# Altium schematic sheet FPGA JTAG and FLASH.SchDoc
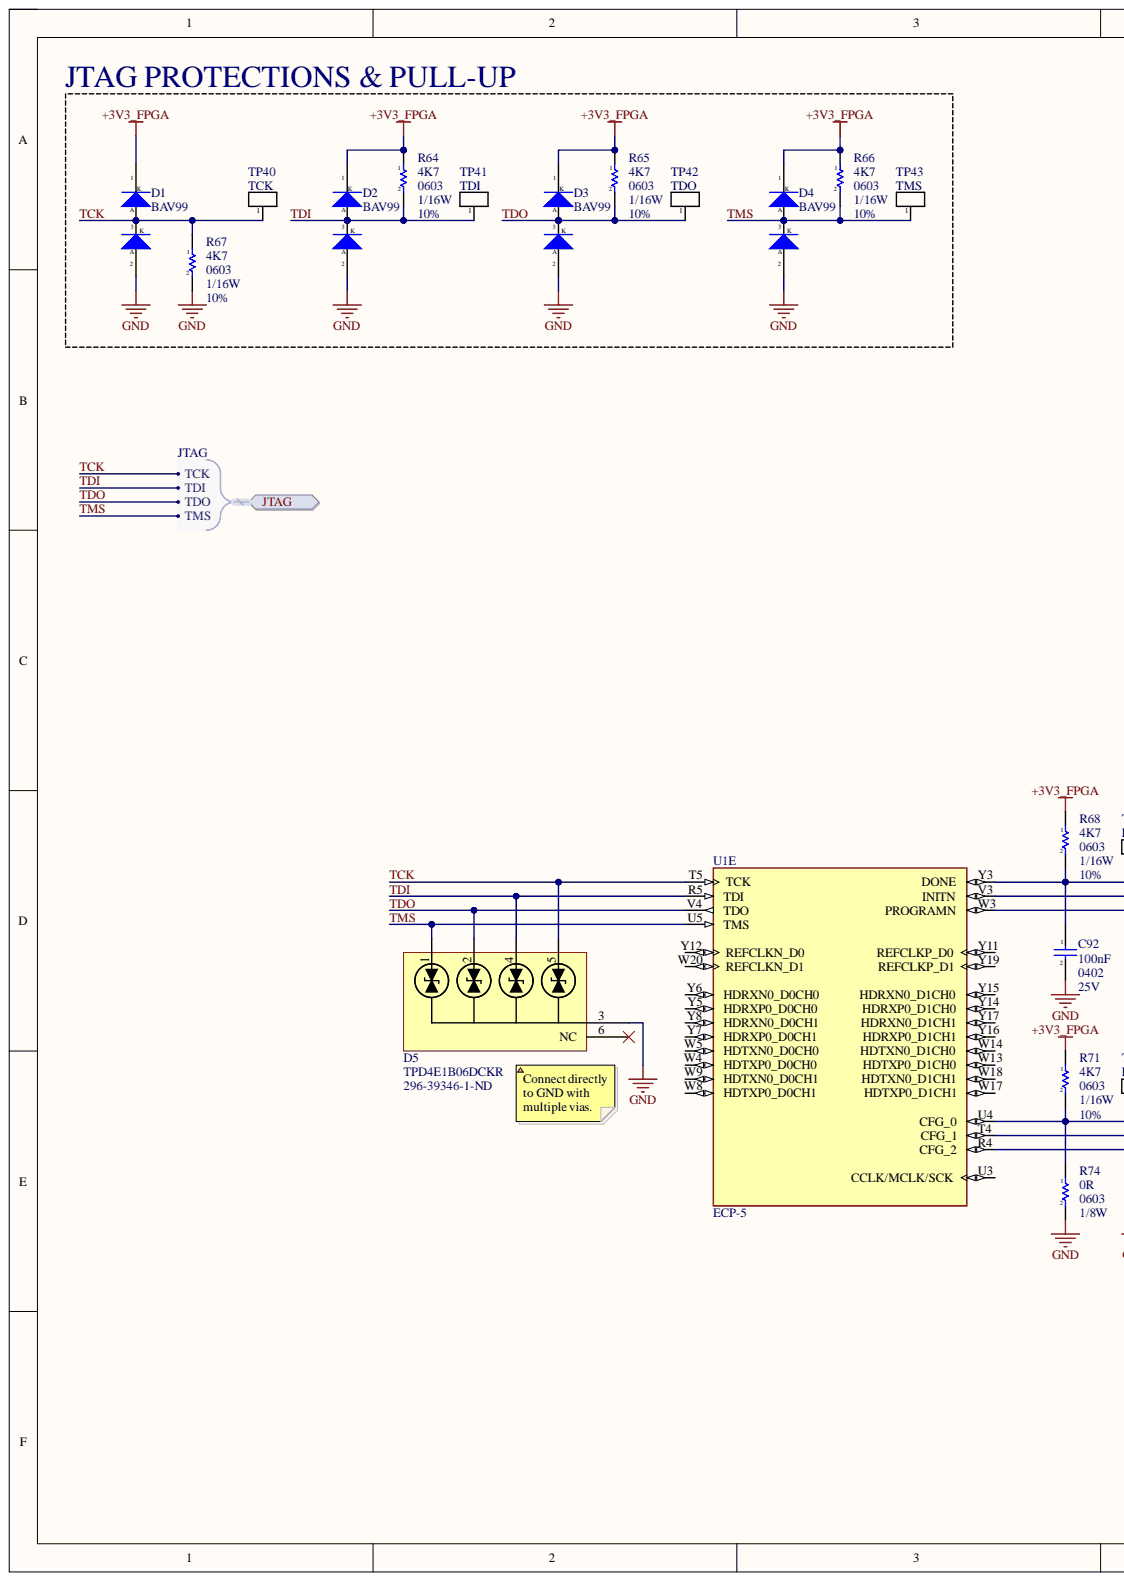
[diagram p0: FPGA JTAG and FLASH.SchDoc, left]
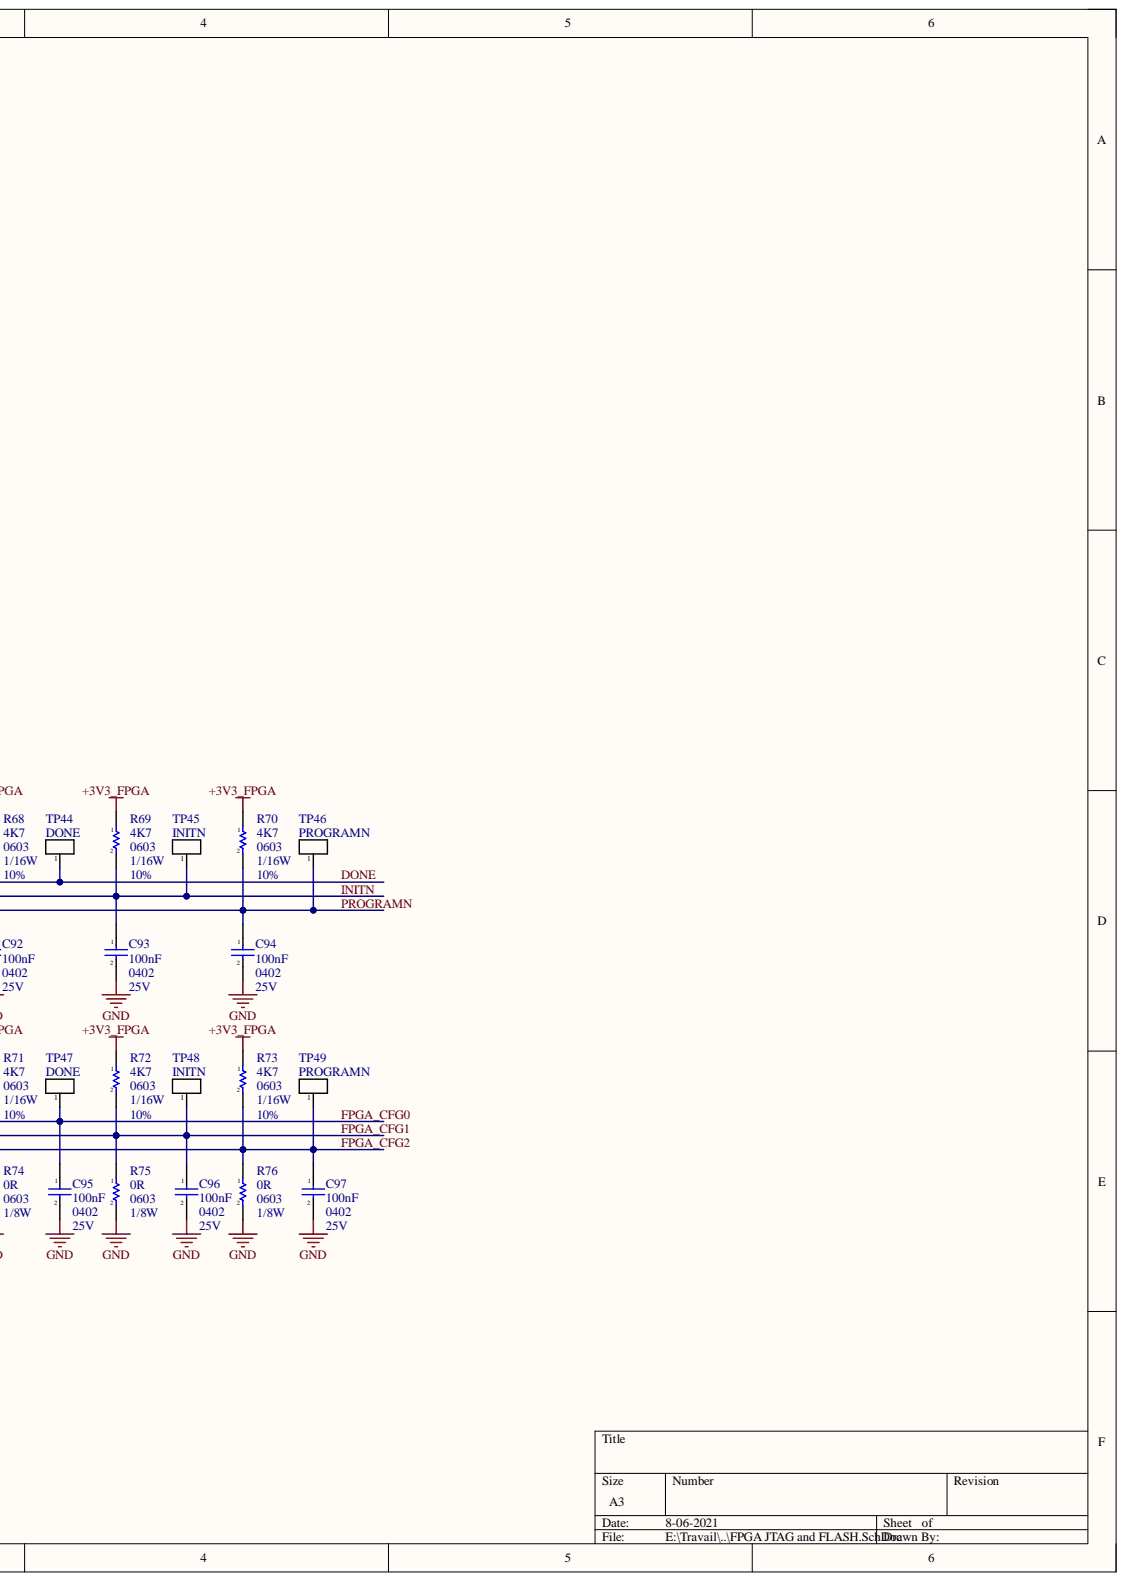
[diagram p1: FPGA JTAG and FLASH.SchDoc, right]

## Parts
C92  100nF  Voltage - Rated: 25V  [0402]
C93  100nF  Voltage - Rated: 25V  [0402]
C94  100nF  Voltage - Rated: 25V  [0402]
C95  100nF  Voltage - Rated: 25V  [0402]
C96  100nF  Voltage - Rated: 25V  [0402]
C97  100nF  Voltage - Rated: 25V  [0402]
D1  BAV99  [SOT-23]
D2  BAV99  [SOT-23]
D3  BAV99  [SOT-23]
D4  BAV99  [SOT-23]
D5  TPD4E1B06DCKR  Supplier Part Number 1: 296-39346-1-ND  [TPD4E1B06DCKR]
R64  4K7  Package / Case: 0603  Tolerance: 10%  [RES_0603]
R65  4K7  Package / Case: 0603  Power: 1/16W  Tolerance: 10%  [RES_0603]
R66  4K7  Package / Case: 0603  Tolerance: 10%  [RES_0603]
R67  4K7  Package / Case: 0603  Power: 1/16W  Tolerance: 10%  [RES_0603]
R68  4K7  Package / Case: 0603  Power: 1/16W  Tolerance: 10%  [RES_0603]
R69  4K7  Package / Case: 0603  Tolerance: 10%  [RES_0603]
R70  4K7  Package / Case: 0603  Power: 1/16W  Tolerance: 10%  [RES_0603]
R71  4K7  Package / Case: 0603  Power: 1/16W  Tolerance: 10%  [RES_0603]
R72  4K7  Package / Case: 0603  Tolerance: 10%  [RES_0603]
R73  4K7  Package / Case: 0603  Power: 1/16W  Tolerance: 10%  [RES_0603]
R74  0R  Package / Case: 0603  Power: 1/8W  [RES_0603]
R75  0R  Package / Case: 0603  Power: 1/8W  [RES_0603]
R76  0R  Package / Case: 0603  Power: 1/8W  [RES_0603]
TP40  TCK  [TP_PAD]
TP41  TDI  [TP_PAD]
TP42  TDO  [TP_PAD]
TP43  TMS  [TP_PAD]
TP44  DONE  [TP_PAD]
TP45  INITN  [TP_PAD]
TP46  PROGRAMN  [TP_PAD]
TP47  DONE  [TP_PAD]
TP48  INITN  [TP_PAD]
TP49  PROGRAMN  [TP_PAD]
U1  ECP-5  [CABGA-381]  (part E)

## Ports
JTAG, JTAG.TCK, JTAG.TDI, JTAG.TDO, JTAG.TMS

## Nets
+3V3_FPGA: D1.1 D2.1 D3.1 D4.1 R64.1 R65.1 R66.1 R68.1 R69.1 R70.1 R71.1 R72.1 R73.1
GND: C92.2 C93.2 C94.2 C95.2 C96.2 C97.2 D1.2 D2.2 D3.2 D4.2 D5.3 R67.2 R74.2 R75.2 R76.2
DONE: C92.1 R68.2 TP44.1 U1.Y3(DONE)
FPGA_CFG0: C95.1 R71.2 R74.1 TP47.1 U1.U4(CFG_0)
FPGA_CFG1: C96.1 R72.2 R75.1 TP48.1 U1.T4(CFG_1)
FPGA_CFG2: C97.1 R73.2 R76.1 TP49.1 U1.R4(CFG_2)
INITN: C93.1 R69.2 TP45.1 U1.V3(INITN)
PROGRAMN: C94.1 R70.2 TP46.1 U1.W3(PROGRAMN)
TCK: D1.3 D5.5 R67.1 TP40.1 U1.T5(TCK) ; port JTAG
TDI: D2.3 D5.4 R64.2 TP41.1 U1.R5(TDI) ; port JTAG
TDO: D3.3 D5.2 R65.2 TP42.1 U1.V4(TDO) ; port JTAG
TMS: D4.3 D5.1 R66.2 TP43.1 U1.U5(TMS) ; port JTAG

Not connected: D5 6(NC); U1 U3(CCLK/MCLK/SCK) W4(HDTXP0_D0CH0) W5(HDTXN0_D0CH0) W8(HDTXP0_D0CH1) W9(HDTXN0_D0CH1) W13(HDTXP0_D1CH0) W14(HDTXN0_D1CH0) W17(HDTXP0_D1CH1) W18(HDTXN0_D1CH1) W20(REFCLKN_D1) Y5(HDRXP0_D0CH0) Y6(HDRXN0_D0CH0) Y7(HDRXP0_D0CH1) Y8(HDRXN0_D0CH1) Y11(REFCLKP_D0) Y12(REFCLKN_D0) Y14(HDRXP0_D1CH0) Y15(HDRXN0_D1CH0) Y16(HDRXP0_D1CH1) Y17(HDRXN0_D1CH1) Y19(REFCLKP_D1)

## Library data of the parts
C92-C97 = CAP_CER: Generic SMT Ceramic Capacitor; Component Kind: Standard; ComponentLink1Description: Digikey; ComponentLink1URL: [Digikey Link]; ComponentLink2Description: Datasheet; ComponentLink2URL: [Datasheet Link]; Library Name: RaesLib.IntLib
D1-D4 = DIODE_BAV99: DIODE ARRAY GP 75V 300MA SOT23-3; Mfr: Taiwan Semiconductor Corporation; Current: 200mA; DC Reverse Voltage: 70V; Diode Configuration: 1 Pair Series Connection; Component Kind: Standard; Operating Temperature: -55C - 150C; Library Name: CryptLib.IntLib
D5 = DIODE_TPD4E1B06DCKR: TVS DIODE 5.5VWM 14.5VC SC70-6; Mfr: Texas Instruments; Breakdown Voltage: 7V; Clamping Voltage: 14.5V; Current Peak (10/1ms): 3A; Power Peak: 45W; Voltage - Reverse: 5.5V; Component Kind: Standard; Operating Temperature: -40C - 125C; Supplier 1: Digi-Key; Library Name: CryptLib.IntLib
R64 R66 R69 R72 = RESISTOR: Power: 1/16W; Component Kind: Standard; ComponentLink1Description: Digikey; Description: Resistor; Library Name: RaesLib.IntLib
R65 R67 R68 R70 R71 R73-R76 = RESISTOR: Component Kind: Standard; ComponentLink1Description: Digikey; Description: Resistor
  R74-R76: Library Name: Symbols.SchLib
  R65 R67 R68 R70 R71 R73: Library Name: RaesLib.IntLib
TP40-TP49 = TESTPOINT: Test Point; Component Kind: Standard; Library Name: Symbols.SchLib
U1 = IC_LFE5UM-45F-8BG381C: IC FPGA 203 I/O 381CABGA; Mfr: Lattice Semiconductors; IOs: 203; LABs/CLBs: 11000; Logic Elements: 44000; RAM Bits: 1990656; Supply Voltage: 1.045V - 1.115V; Component Kind: Standard; Operating Temperature: 0C - 85C; Package / Case: 381-CABGA; Library Name: CryptLib.SchLib
